# Altium schematic sheet LED.SchDoc
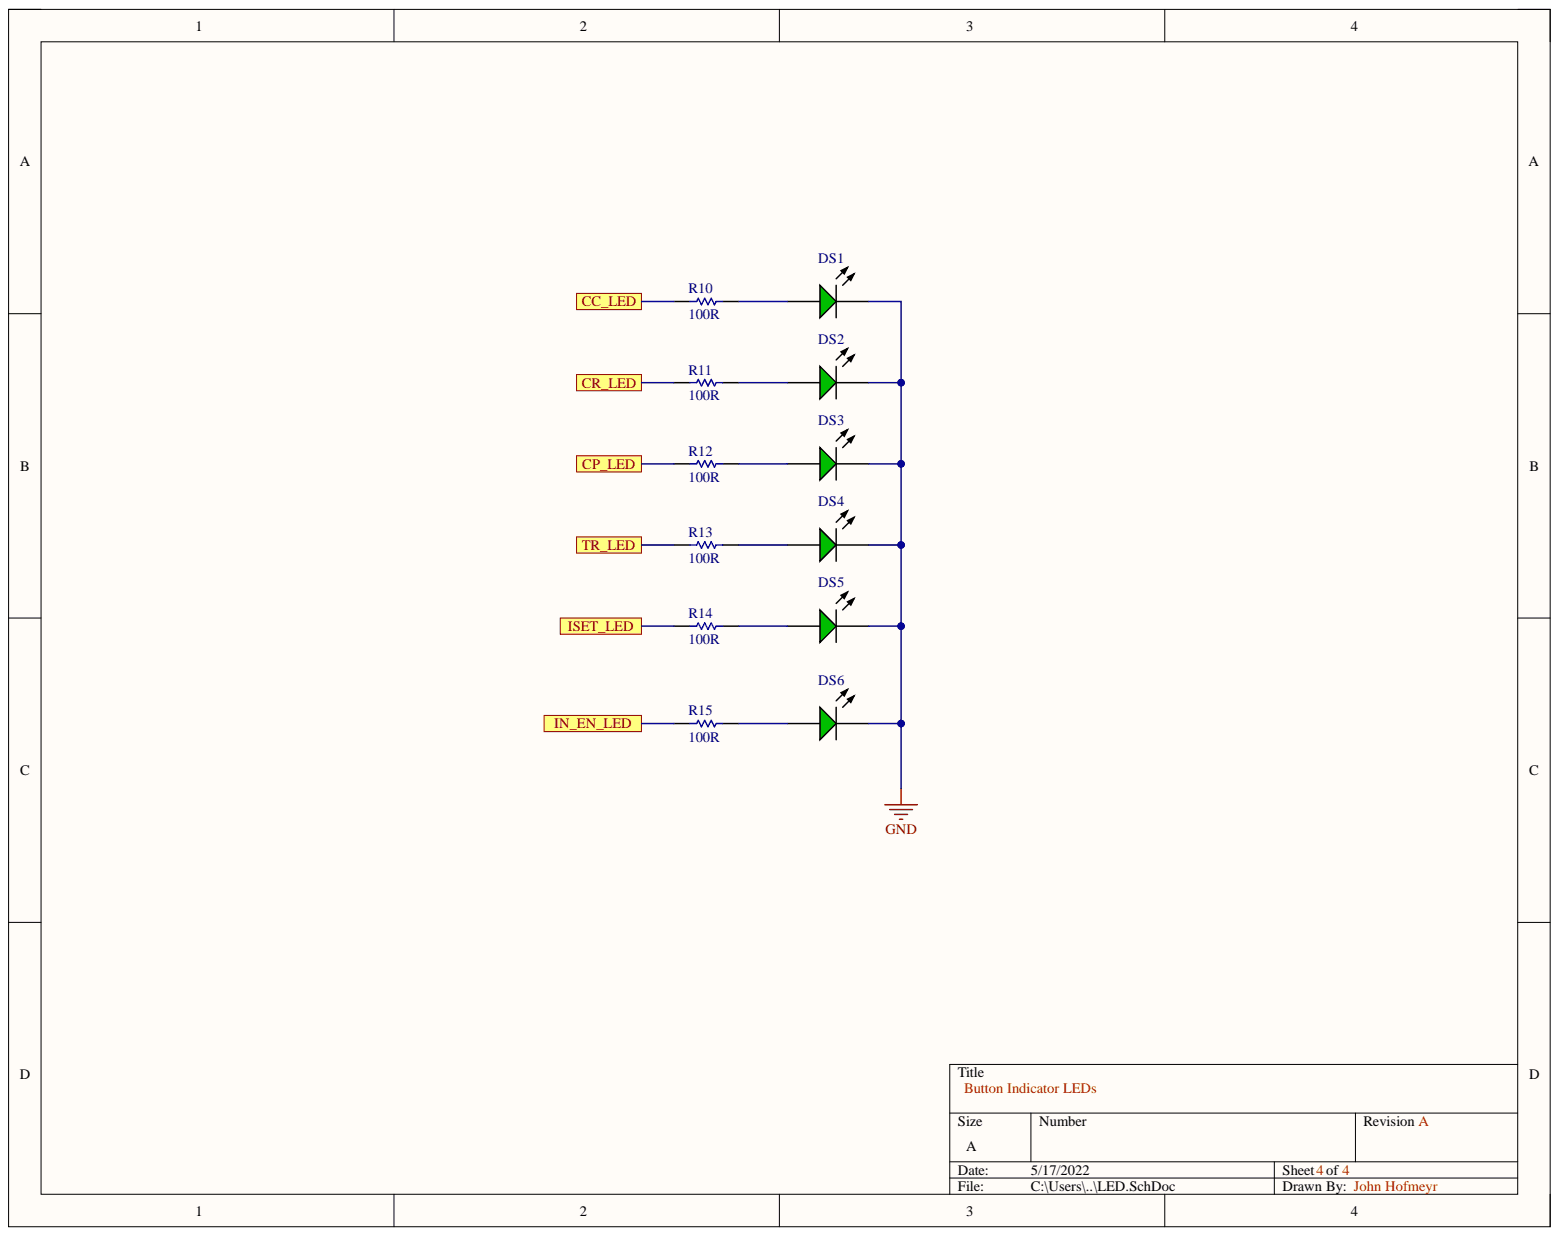
[diagram p0: LED.SchDoc]

## Parts
DS1  HLMP-1790  [LED-2P-2.54-3MM_V]  (value not drawn)
DS2  HLMP-1790  [LED-2P-2.54-3MM_V]  (value not drawn)
DS3  HLMP-1790  [LED-2P-2.54-3MM_V]  (value not drawn)
DS4  HLMP-1790  [LED-2P-2.54-3MM_V]  (value not drawn)
DS5  HLMP-1790  [LED-2P-2.54-3MM_V]  (value not drawn)
DS6  HLMP-1790  [LED-2P-2.54-3MM_V]  (value not drawn)
R10  100R  [RESC2013X50X30ML20T20]
R11  100R  [RESC2013X50X30ML20T20]
R12  100R  [RESC2013X50X30ML20T20]
R13  100R  [RESC2013X50X30ML20T20]
R14  100R  [RESC2013X50X30ML20T20]
R15  100R  [RESC2013X50X30ML20T20]

## Ports
CC_LED, CP_LED, CR_LED, IN_EN_LED, ISET_LED, TR_LED

## Nets
GND: DS1.2 DS2.2 DS3.2 DS4.2 DS5.2 DS6.2
CC_LED: R10.2 ; port CC_LED
CP_LED: R12.2 ; port CP_LED
CR_LED: R11.2 ; port CR_LED
IN_EN_LED: R15.2 ; port IN_EN_LED
ISET_LED: R14.2 ; port ISET_LED
TR_LED: R13.2 ; port TR_LED
NetDS1_1: DS1.1 R10.1
NetDS2_1: DS2.1 R11.1
NetDS3_1: DS3.1 R12.1
NetDS4_1: DS4.1 R13.1
NetDS5_1: DS5.1 R14.1
NetDS6_1: DS6.1 R15.1

## Library data of the parts
DS1-DS6 = CMP-2000-05186-1: LED, Green, 2 mA, 1.9 V, -20 to 100 degC, 2-Pin THD, RoHS, Bulk; Manufacturer: Avago; Dominant Wavelength/Color Temperature: 569nm; Intensity: 2.3mcd; Lens Appearance: ColoredDiffused; Lens Shape Type: Circular; Mounting Orientation: TopMount; Number of LEDs: 1; Peak Wavelength: 565nm; Rad Hard: No; Type: Uni-Color; Viewing Angle: 50deg; Category: LEDs; Color: Green; Component Kind: Standard; Datasheet URL: https://datasheet.ciiva.com/11773/0900766b800204ad-11773539.pdf; Datasheet Version: Jul-14; Library Name: Altium Content Vault; Manufacturer URL: http://www.avagotech.com/; Mounting: ThroughHole; Package: 2T-1; Packaging: Bulk; Pin Count: 2
R10-R15 = CMP-2001-03735-1: Manufacturer: Vishay Dale; Part Number: CRCW0805270RFKEA; Component Kind: Standard; Library Name: Altium Content Vault
